# Altium schematic sheet ZYNQ_PL_BANK.SchDoc(ZYNQ_PL_BANK)
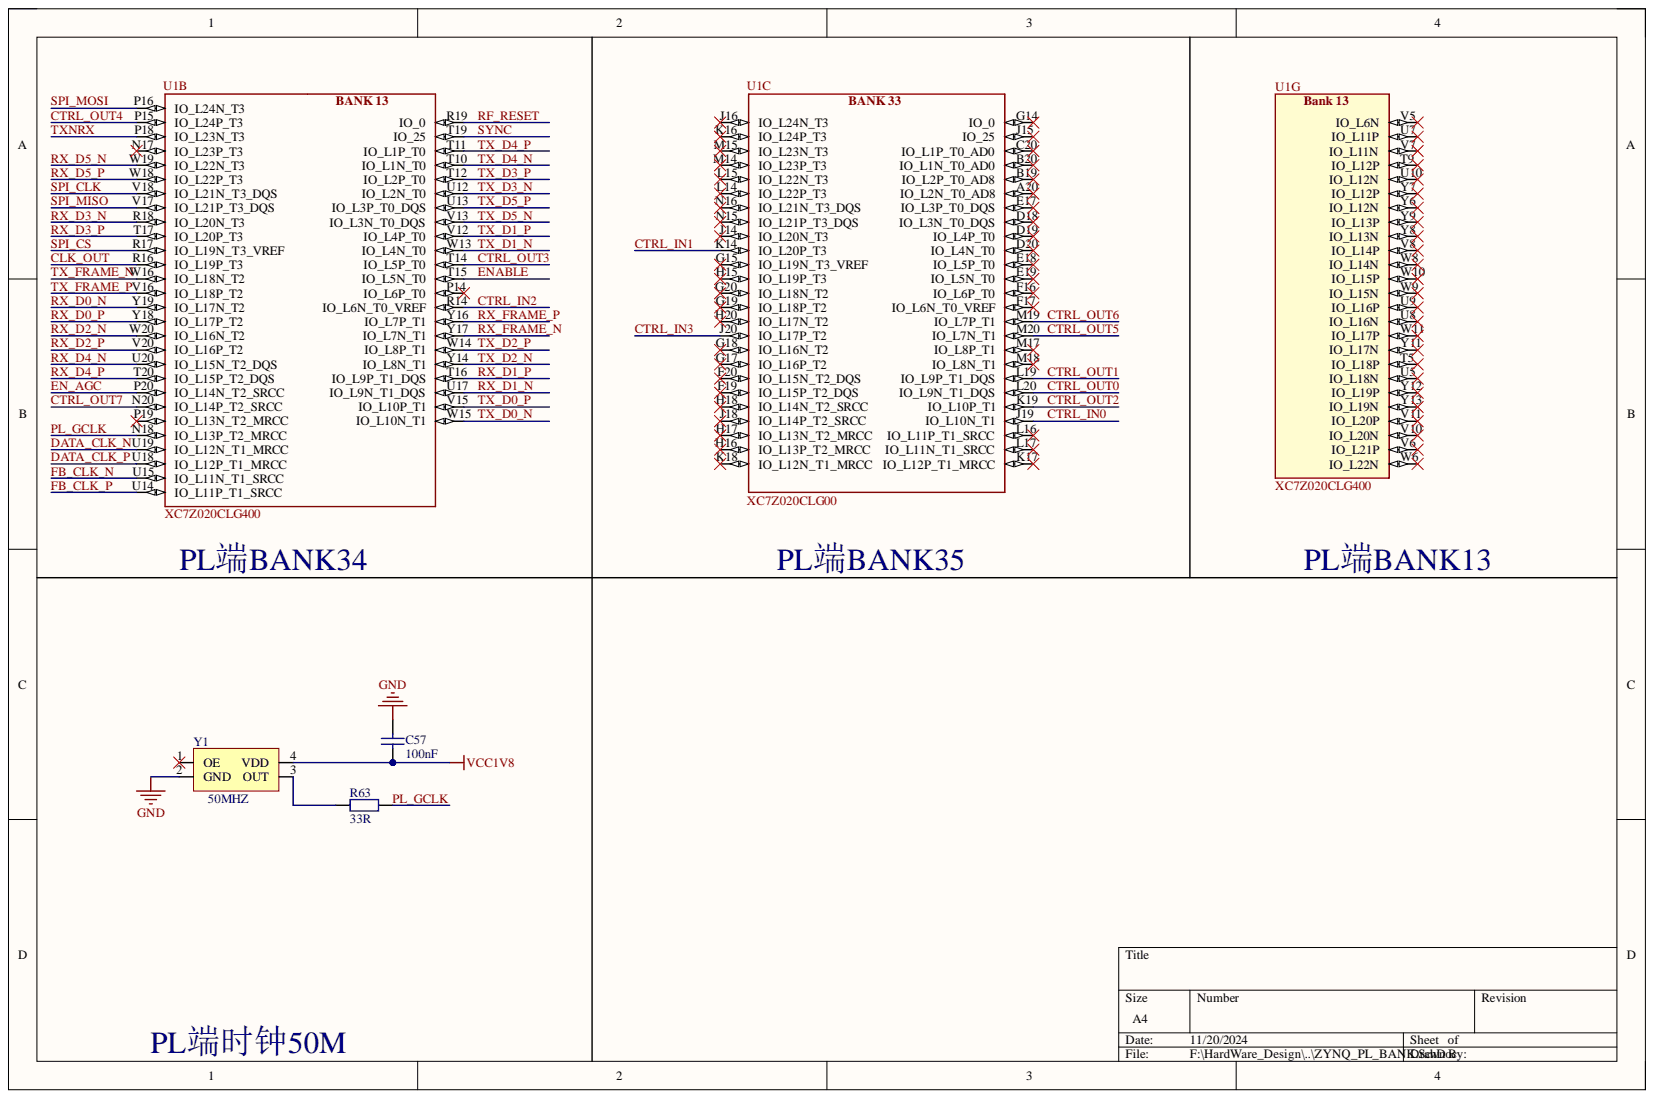
[diagram p0: ZYNQ_PL_BANK.SchDoc]

## Parts
C57  100nF  [C 0402_L]
R63  33R  [R 0402_L]
U1  XC7Z020CLG400  [BGA400C80P20X20_1700X1700X160]  (parts B,C,G)
Y1  50M  value 50MHZ  [OSC 3225-4P]

## Nets
GND: C57.1 Y1.2(GND)
VCC1V8: C57.2 Y1.4(VDD)
CLK_OUT: U1.R16(IO_L19P_T3)
CTRL_IN0: U1.J19(IO_L10N_T1)
CTRL_IN1: U1.K14(IO_L20P_T3)
CTRL_IN2: U1.R14(IO_L6N_T0_VREF)
CTRL_IN3: U1.J20(IO_L17P_T2)
CTRL_OUT0: U1.L20(IO_L9N_T1_DQS)
CTRL_OUT1: U1.L19(IO_L9P_T1_DQS)
CTRL_OUT2: U1.K19(IO_L10P_T1)
CTRL_OUT3: U1.T14(IO_L5P_T0)
CTRL_OUT4: U1.P15(IO_L24P_T3)
CTRL_OUT5: U1.M20(IO_L7N_T1)
CTRL_OUT6: U1.M19(IO_L7P_T1)
CTRL_OUT7: U1.N20(IO_L14P_T2_SRCC)
DATA_CLK_N: U1.U19(IO_L12N_T1_MRCC)
DATA_CLK_P: U1.U18(IO_L12P_T1_MRCC)
EN_AGC: U1.P20(IO_L14N_T2_SRCC)
ENABLE: U1.T15(IO_L5N_T0)
FB_CLK_N: U1.U15(IO_L11N_T1_SRCC)
FB_CLK_P: U1.U14(IO_L11P_T1_SRCC)
PL_GCLK: R63.1 U1.N18(IO_L13P_T2_MRCC)
RF_RESET: U1.R19(IO_0)
RX_D0_N: U1.Y19(IO_L17N_T2)
RX_D0_P: U1.Y18(IO_L17P_T2)
RX_D1_N: U1.U17(IO_L9N_T1_DQS)
RX_D1_P: U1.T16(IO_L9P_T1_DQS)
RX_D2_N: U1.W20(IO_L16N_T2)
RX_D2_P: U1.V20(IO_L16P_T2)
RX_D3_N: U1.R18(IO_L20N_T3)
RX_D3_P: U1.T17(IO_L20P_T3)
RX_D4_N: U1.U20(IO_L15N_T2_DQS)
RX_D4_P: U1.T20(IO_L15P_T2_DQS)
RX_D5_N: U1.W19(IO_L22N_T3)
RX_D5_P: U1.W18(IO_L22P_T3)
RX_FRAME_N: U1.Y17(IO_L7N_T1)
RX_FRAME_P: U1.Y16(IO_L7P_T1)
SPI_CLK: U1.V18(IO_L21N_T3_DQS)
SPI_CS: U1.R17(IO_L19N_T3_VREF)
SPI_MISO: U1.V17(IO_L21P_T3_DQS)
SPI_MOSI: U1.P16(IO_L24N_T3)
SYNC: U1.T19(IO_25)
TX_D0_N: U1.W15(IO_L10N_T1)
TX_D0_P: U1.V15(IO_L10P_T1)
TX_D1_N: U1.W13(IO_L4N_T0)
TX_D1_P: U1.V12(IO_L4P_T0)
TX_D2_N: U1.Y14(IO_L8N_T1)
TX_D2_P: U1.W14(IO_L8P_T1)
TX_D3_N: U1.U12(IO_L2N_T0)
TX_D3_P: U1.T12(IO_L2P_T0)
TX_D4_N: U1.T10(IO_L1N_T0)
TX_D4_P: U1.T11(IO_L1P_T0)
TX_D5_N: U1.V13(IO_L3N_T0_DQS)
TX_D5_P: U1.U13(IO_L3P_T0_DQS)
TX_FRAME_N: U1.W16(IO_L18N_T2)
TX_FRAME_P: U1.V16(IO_L18P_T2)
TXNRX: U1.P18(IO_L23N_T3)
NetR63_2: R63.2 Y1.3(OUT)

Not connected: U1 A20(IO_L2N_T0_AD8) B19(IO_L2P_T0_AD8) B20(IO_L1N_T0_AD0) C20(IO_L1P_T0_AD0) D18(IO_L3N_T0_DQS) D19(IO_L4P_T0) D20(IO_L4N_T0) E17(IO_L3P_T0_DQS) E18(IO_L5P_T0) E19(IO_L5N_T0) F16(IO_L6P_T0) F17(IO_L6N_T0_VREF) F19(IO_L15P_T2_DQS) F20(IO_L15N_T2_DQS) G14(IO_0) G15(IO_L19N_T3_VREF) G17(IO_L16P_T2) G18(IO_L16N_T2) G19(IO_L18P_T2) G20(IO_L18N_T2) H15(IO_L19P_T3) H16(IO_L13P_T2_MRCC) H17(IO_L13N_T2_MRCC) H18(IO_L14N_T2_SRCC) H20(IO_L17N_T2) J14(IO_L20N_T3) J15(IO_25) J16(IO_L24N_T3) J18(IO_L14P_T2_SRCC) K16(IO_L24P_T3) K17(IO_L12P_T1_MRCC) K18(IO_L12N_T1_MRCC) L14(IO_L22P_T3) L15(IO_L22N_T3) L16(IO_L11P_T1_SRCC) L17(IO_L11N_T1_SRCC) M14(IO_L23P_T3) M15(IO_L23N_T3) M17(IO_L8P_T1) M18(IO_L8N_T1) N15(IO_L21P_T3_DQS) N16(IO_L21N_T3_DQS) N17(IO_L23P_T3) P14(IO_L6P_T0) P19(IO_L13N_T2_MRCC) T5(IO_L18P) T9(IO_L12P) U5(IO_L18N) U7(IO_L11P) U8(IO_L16N) U9(IO_L16P) U10(IO_L12N) V5(IO_L6N) V6(IO_L21P) V7(IO_L11N) V8(IO_L14P) V10(IO_L20N) V11(IO_L20P) W6(IO_L22N) W8(IO_L14N) W9(IO_L15N) W10(IO_L15P) W11(IO_L17P) Y6(IO_L12N) Y7(IO_L12P) Y8(IO_L13N) Y9(IO_L13P) Y11(IO_L17N) Y12(IO_L19P) Y13(IO_L19N); Y1 1(OE)

## Library data of the parts
C57 = Cap1: Component Kind: Standard; value: 100nF; Library Name: 零散元件库1.SCHLIB
R63 = Res: Component Kind: Standard; value: 33R; Library Name: 零散元件库1.SCHLIB
U1 = XC7Z020: Zynq 7000 FPGA 20 Die, in a CSG 484 Package; Manufacturer: Xilinx; Component Kind: Standard; Library Name: ZYNQ_PCB.SCHLIB
Y1 = XATLS: 贴片有源晶振; Component Kind: Standard; Library Name: 2.0 - 保险丝-晶振-光耦.SchLib; 封装库来自淘宝卖家 ID：黄晶宝石: https://shop463601444.taobao.com
